# Altium schematic sheet 09 - Audio Amplifier.SchDoc
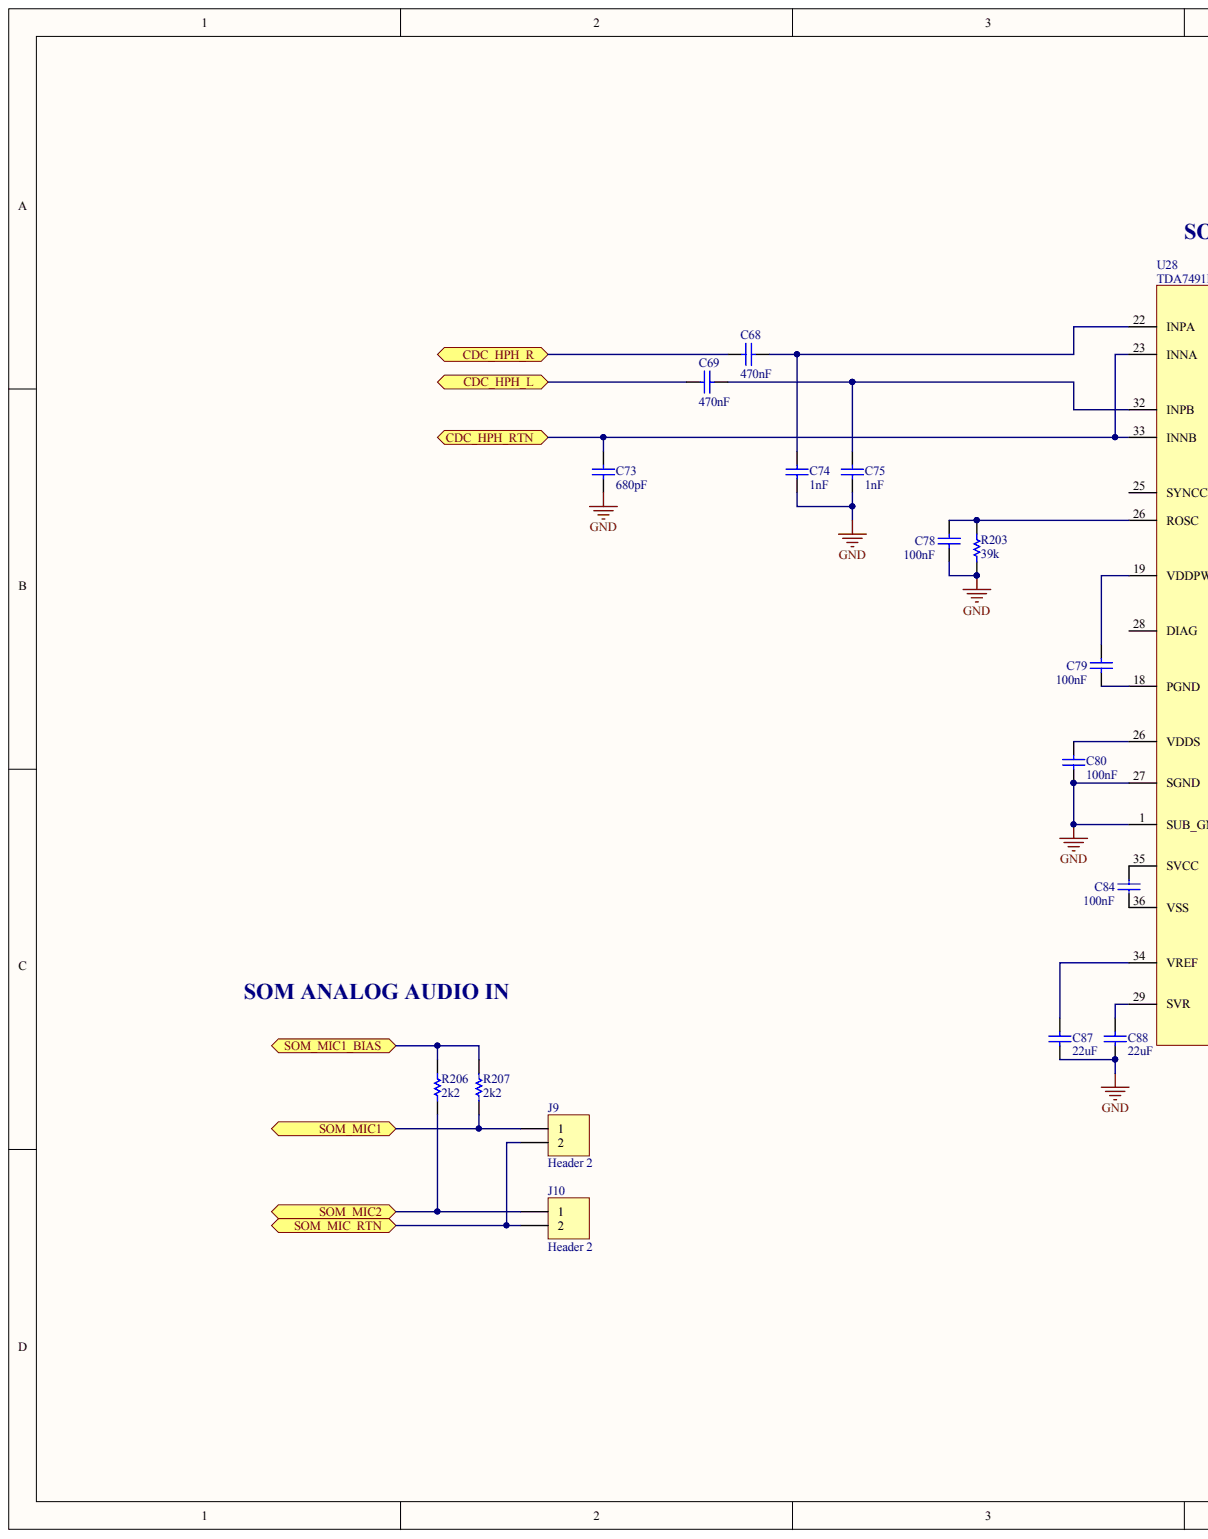
[diagram p0: 09 - Audio Amplifier.SchDoc, left]
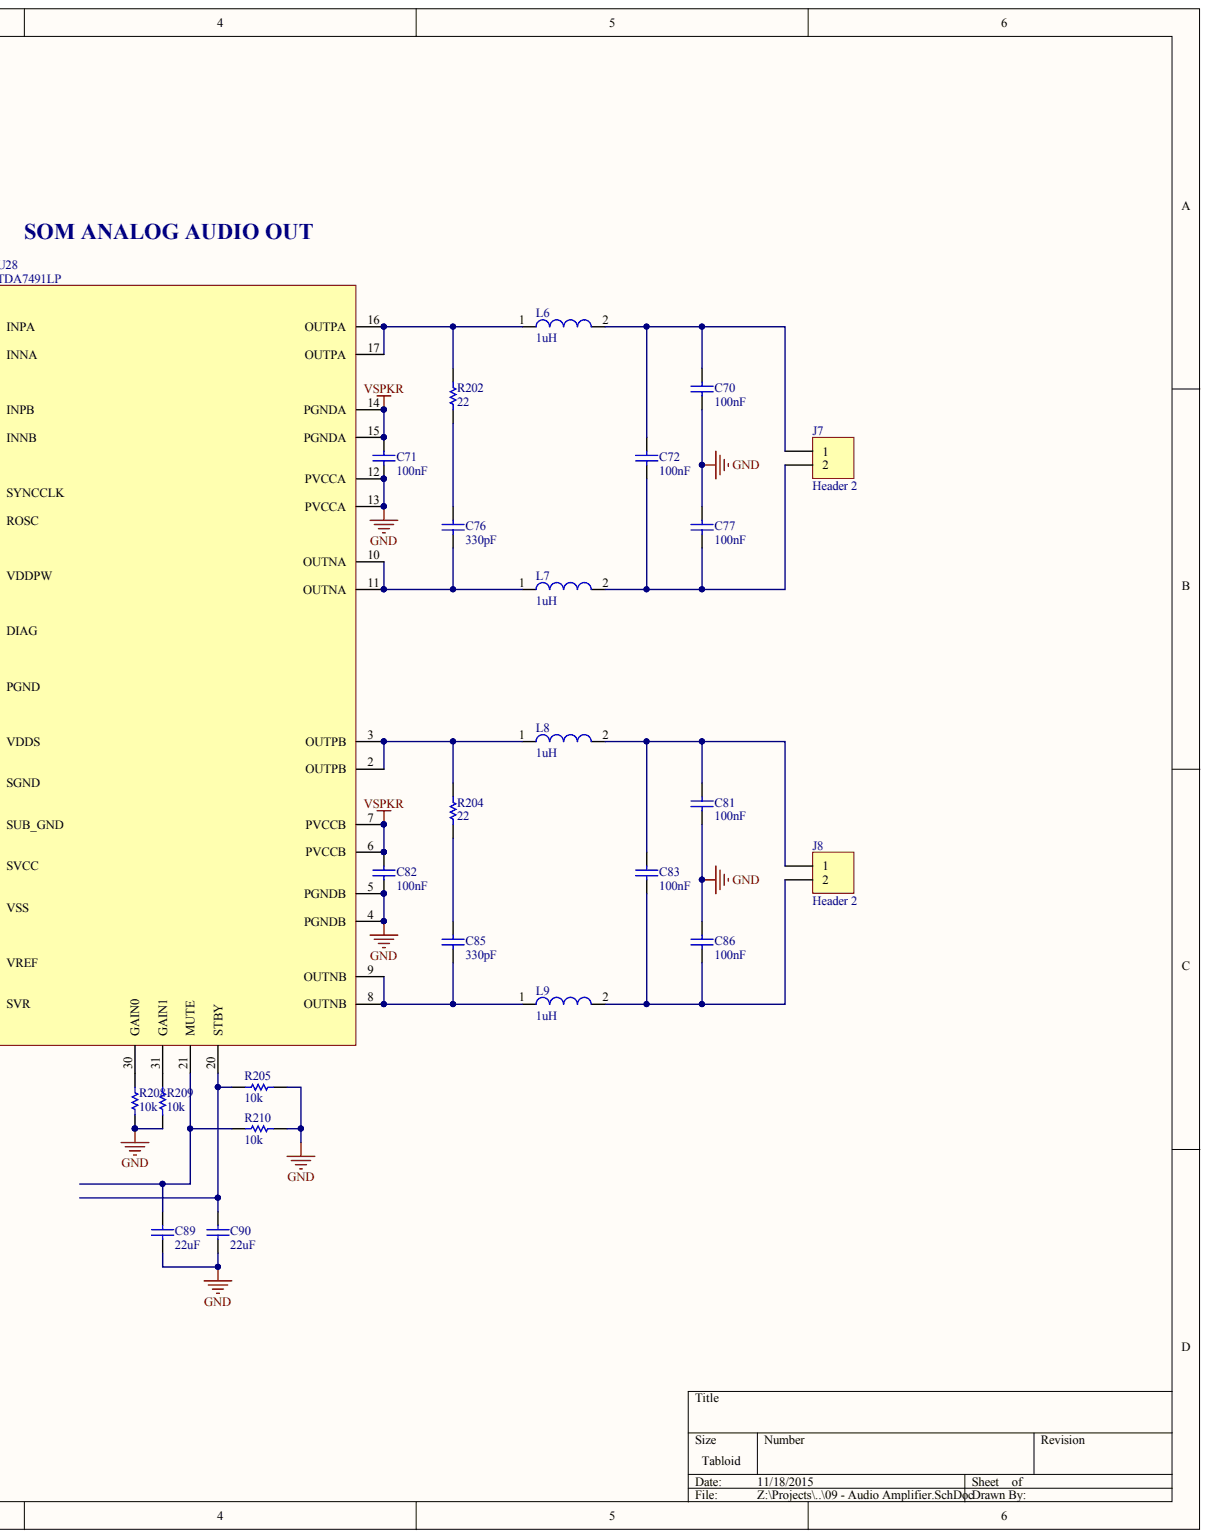
[diagram p1: 09 - Audio Amplifier.SchDoc, right]

## Parts
C68  value 470nF  [CAPC0805(2012)100_N]
C69  value 470nF  [CAPC0805(2012)100_N]
C70  value 100nF  [CAPC0805(2012)100_N]  (hidden comment: CAP 100nF 25V 0805(2012))
C71  value 100nF  [CAPC0805(2012)100_N]  (hidden comment: CAP 100nF 25V 0805(2012))
C72  value 100nF  [CAPC0805(2012)100_N]  (hidden comment: CAP 100nF 25V 0805(2012))
C73  value 680pF  [CAPC0805(2012)100_L]
C74  value 1nF  [CAPC0805(2012)100_N]
C75  value 1nF  [CAPC0805(2012)100_N]
C76  value 330pF  [CAPC0805(2012)100_N]
C77  value 100nF  [CAPC0805(2012)100_N]  (hidden comment: CAP 100nF 25V 0805(2012))
C78  value 100nF  [CAPC0805(2012)100_N]  (hidden comment: CAP 100nF 25V 0805(2012))
C79  value 100nF  [CAPC0805(2012)100_N]  (hidden comment: CAP 100nF 25V 0805(2012))
C80  value 100nF  [CAPC0805(2012)100_N]  (hidden comment: CAP 100nF 25V 0805(2012))
C81  value 100nF  [CAPC0805(2012)100_N]  (hidden comment: CAP 100nF 25V 0805(2012))
C82  value 100nF  [CAPC0805(2012)100_N]  (hidden comment: CAP 100nF 25V 0805(2012))
C83  value 100nF  [CAPC0805(2012)100_N]  (hidden comment: CAP 100nF 25V 0805(2012))
C84  value 100nF  [CAPC0805(2012)100_N]  (hidden comment: CAP 100nF 25V 0805(2012))
C85  value 330pF  [CAPC0805(2012)100_N]
C86  value 100nF  [CAPC0805(2012)100_N]  (hidden comment: CAP 100nF 25V 0805(2012))
C87  value 22uF  [CAPC0805(2012)145_L]  (hidden comment: CAP 22uF 16V 0805(2012))
C88  value 22uF  [CAPC0805(2012)145_L]  (hidden comment: CAP 22uF 16V 0805(2012))
C89  value 22uF  [CAPC0805(2012)145_L]  (hidden comment: CAP 22uF 16V 0805(2012))
C90  value 22uF  [CAPC0805(2012)145_L]  (hidden comment: CAP 22uF 16V 0805(2012))
J7  Header 2  [HDR1X2]
J8  Header 2  [HDR1X2]
J9  Header 2  [HDR1X2]
J10  Header 2  [HDR1X2]
L6  1uH  [SMD 0805_A]
L7  1uH  [SMD 0805_A]
L8  1uH  [SMD 0805_A]
L9  1uH  [SMD 0805_A]
R202  value 22  [RESC0805(2012)_L]
R203  value 39k  [RESC0805(2012)_L]
R204  value 22  [RESC0805(2012)_L]
R205  value 10k  [RESC0805(2012)_M]  (hidden comment: 10K 1% 0805(2012))
R206  value 2k2  [RESC0805(2012)_M]
R207  value 2k2  [RESC0805(2012)_M]
R208  value 10k  [RESC0805(2012)_M]  (hidden comment: 10K 1% 0805(2012))
R209  value 10k  [RESC0805(2012)_M]  (hidden comment: 10K 1% 0805(2012))
R210  value 10k  [RESC0805(2012)_M]  (hidden comment: 10K 1% 0805(2012))
U28  TDA7491LP

## Ports
CDC_HPH_L, CDC_HPH_R, CDC_HPH_RTN, SOM_MIC1, SOM_MIC1_BIAS, SOM_MIC2, SOM_MIC_RTN

## Nets
GND: C70.1 C71.1 C73.1 C74.1 C75.1 C77.2 C78.1 C80.2 C81.1 C82.1 C86.2 C87.1 C88.1 C89.1 C90.1 R203.1 R205.2 R208.2 R209.2 R210.2 U28.1(SUB_GND) U28.4(PGNDB) U28.5(PGNDB) U28.12(PVCCA) U28.13(PVCCA) U28.27(SGND)
VSPKR: C71.2 C82.2 U28.6(PVCCB) U28.7(PVCCB) U28.14(PGNDA) U28.15(PGNDA)
CDC_HPH_L: C69.2 ; port CDC_HPH_L
CDC_HPH_R: C68.2 ; port CDC_HPH_R
CDC_HPH_RTN: C73.2 U28.23(INNA) U28.33(INNB) ; port CDC_HPH_RTN
SOM_MIC1: J9.1 R207.2 ; port SOM_MIC1
SOM_MIC1_BIAS: R206.1 R207.1 ; port SOM_MIC1_BIAS
SOM_MIC2: J10.1 R206.2 ; port SOM_MIC2
SOM_MIC_RTN: J9.2 J10.2 ; port SOM_MIC_RTN
NetC68_1: C68.1 C74.2 U28.22(INPA)
NetC69_1: C69.1 C75.2 U28.32(INPB)
NetC70_2: C70.2 C72.2 J7.1 L6.2
NetC72_1: C72.1 C77.1 J7.2 L7.2
NetC76_1: C76.1 L7.1 U28.10(OUTNA) U28.11(OUTNA)
NetC76_2: C76.2 R202.1
NetC78_2: C78.2 R203.2 U28.26
NetC79_1: C79.1 U28.18(PGND)
NetC79_2: C79.2 U28.19(VDDPW)
NetC80_1: C80.1 U28.26
NetC81_2: C81.2 C83.2 J8.1 L8.2
NetC83_1: C83.1 C86.1 J8.2 L9.2
NetC84_1: C84.1 U28.36(VSS)
NetC84_2: C84.2 U28.35(SVCC)
NetC85_1: C85.1 L9.1 U28.8(OUTNB) U28.9(OUTNB)
NetC85_2: C85.2 R204.1
NetC87_2: C87.2 U28.34(VREF)
NetC88_2: C88.2 U28.29(SVR)
NetC89_2: C89.2 R210.1 U28.21(MUTE)
NetC90_2: C90.2 R205.1 U28.20(STBY)
NetL6_1: L6.1 R202.2 U28.16(OUTPA) U28.17(OUTPA)
NetL8_1: L8.1 R204.2 U28.2(OUTPB) U28.3(OUTPB)
NetR208_1: R208.1 U28.30(GAIN0)
NetR209_1: R209.1 U28.31(GAIN1)

Not connected: U28 25(SYNCCLK) 28(DIAG)

## Library data of the parts
C68 C69 C74 C75 = CMP-1036-04411-1: Case-EIA: 0805; Case-Metric: 2012; Rated Voltage: 25 V; Tolerance: Â±10%; Component Kind: Standard; Library Name: Altium Content Vault; Max Thickness: 1 mm; Technology: SMT
C70-C72 C76-C86 = CMP-1036-04411-1: CAP 100nF 25V Â±10% 0805 (2012 Metric) Thickness 1mm SMD; Case-EIA: 0805; Case-Metric: 2012; Component Kind: Standard; Library Name: Altium Content Vault; Max Thickness: 1 mm; Rated Voltage: 25 V; Technology: SMT; Tolerance: Â±10%
C73 = CMP-1036-04520-1: CAP 220nF 6.3V Â±20% 0805 (2012 Metric) Thickness 1mm SMD; Case-EIA: 0805; Case-Metric: 2012; Component Kind: Standard; Library Name: Altium Content Vault; Max Thickness: 1 mm; Rated Voltage: 6.3 V; Technology: SMT; Tolerance: Â±20%
C87-C90 = CMP-1036-04946-1: CAP 22uF 16V Â±20% 0805 (2012 Metric) Thickness 1.45mm SMD; Case-EIA: 0805; Case-Metric: 2012; Component Kind: Standard; Library Name: Altium Content Vault; Max Thickness: 1.45 mm; Rated Voltage: 16 V; Technology: SMT; Tolerance: Â±20%
J7-J10 = Header 2: Header, 2-Pin; Component Kind: Standard; LatestRevisionDate: 17-Jul-2002; LatestRevisionNote: Re-released for DXP Platform.; Library Name: Miscellaneous Connectors.IntLib; Signal Integrity: Connector; Publisher: Altium Limited
L6-L9 = CMP-1392-00033-1: Power Multilayer Inductor WE-PMI, L=1.00 ÂµH; Manufacturer: Wuerth Elektronik; PartNumber: 74479775210; Component Kind: Standard; ComponentLink1Description: Manufacturer URL; ComponentLink1URL: http://www.we-online.com; ComponentLink2Description: Datasheet; ComponentLink2URL: http://katalog.we-online.de/kataloge/eisos/media/pdf/74479775210A.pdf; Inductance: 1.00 ÂµH; Library Name: Altium Content Vault; PackageReference: SMD 0805_A
R202 R204 = CMP-1013-00341-1: 33R 0.125W 1% 0805 (2012 Metric)  SMD; Case-EIA: 0805; Case-Metric: 2012; Component Kind: Standard; Library Name: Altium Content Vault; Power: 0.125 W; Technology: SMT; Tolerance: 1 %
R203 = CMP-1013-00341-1: Case-EIA: 0805; Case-Metric: 2012; Power: 0.125 W; Tolerance: 1 %; Component Kind: Standard; Library Name: Altium Content Vault; Technology: SMT
R205-R210 = CMP-1013-00623-1: 10K 0.125W 1% 0805 (2012 Metric)  SMD; Case-EIA: 0805; Case-Metric: 2012; Component Kind: Standard; Library Name: Altium Inc., Content Vault; Power: 0.125 W; Technology: SMT; Tolerance: 1 %
U28 = TDA7491LP: 2x5W DUAL BTL CLASS-D AUDIO AMPLIFIER; Component Kind: Standard; Library Name: tda7491.SchLib
